# Altium schematic sheet USB.SchDoc
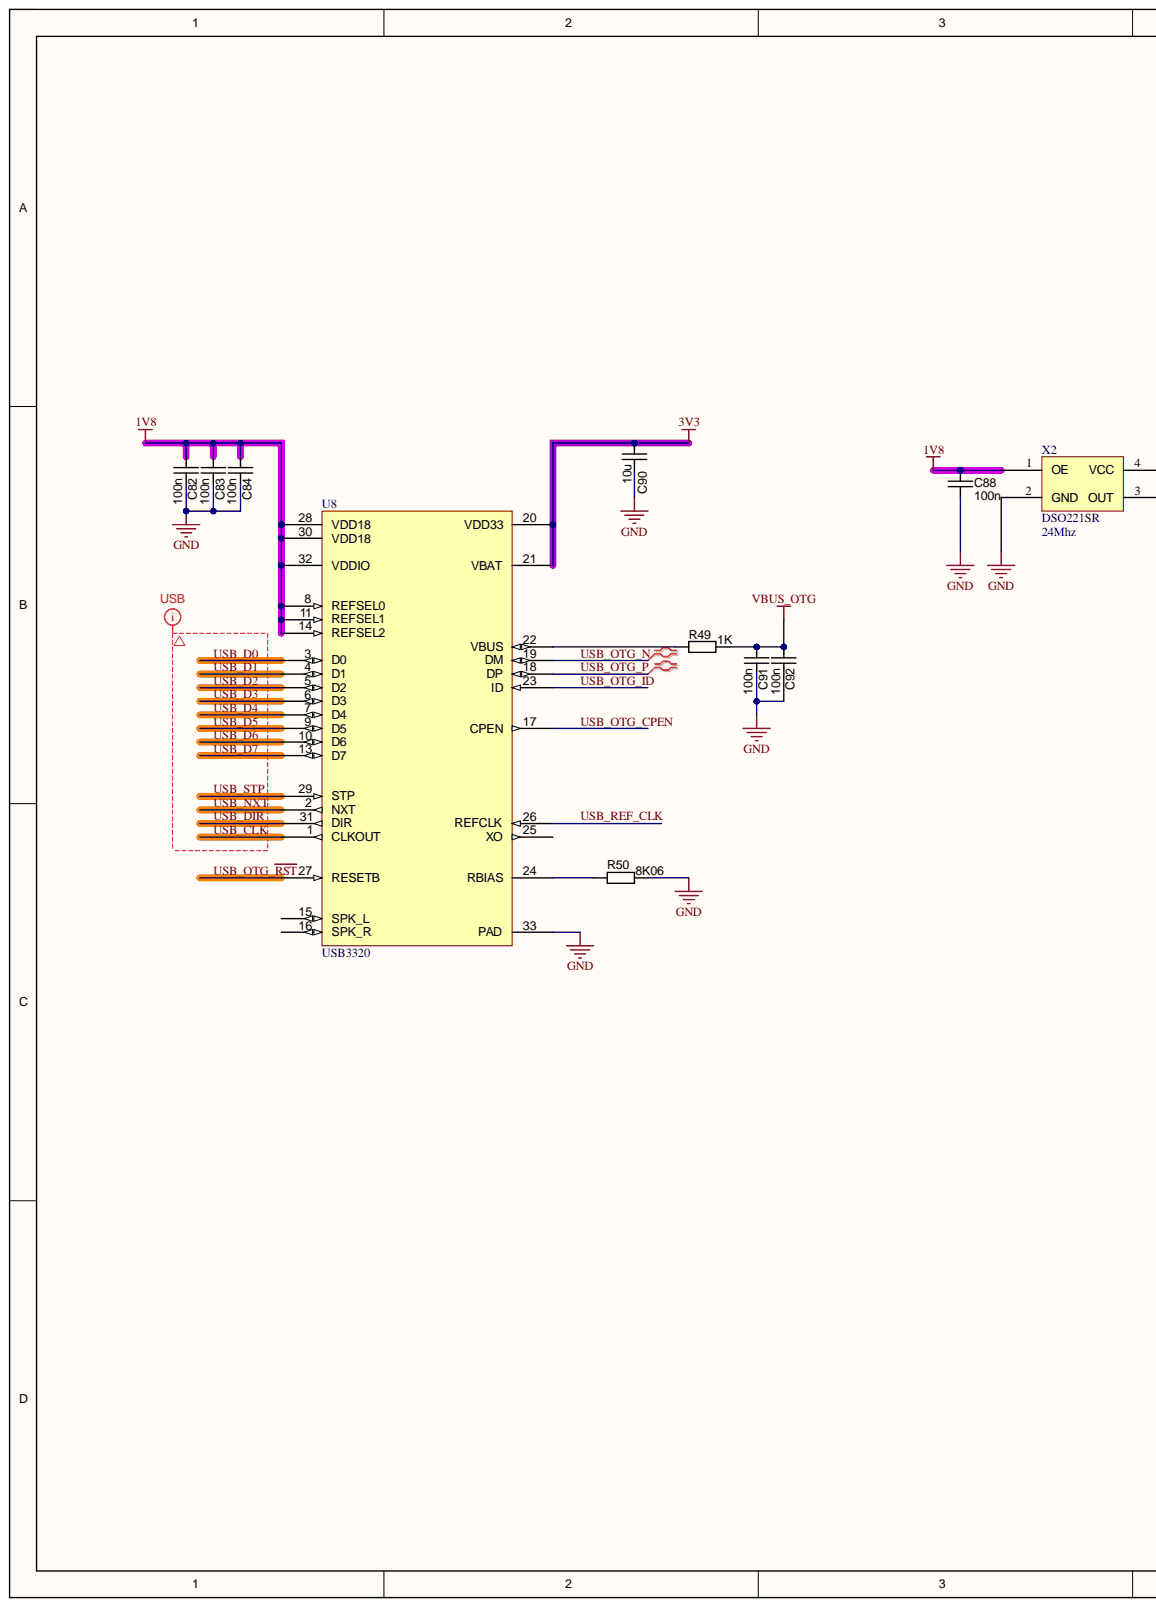
[diagram p0: USB.SchDoc, left]
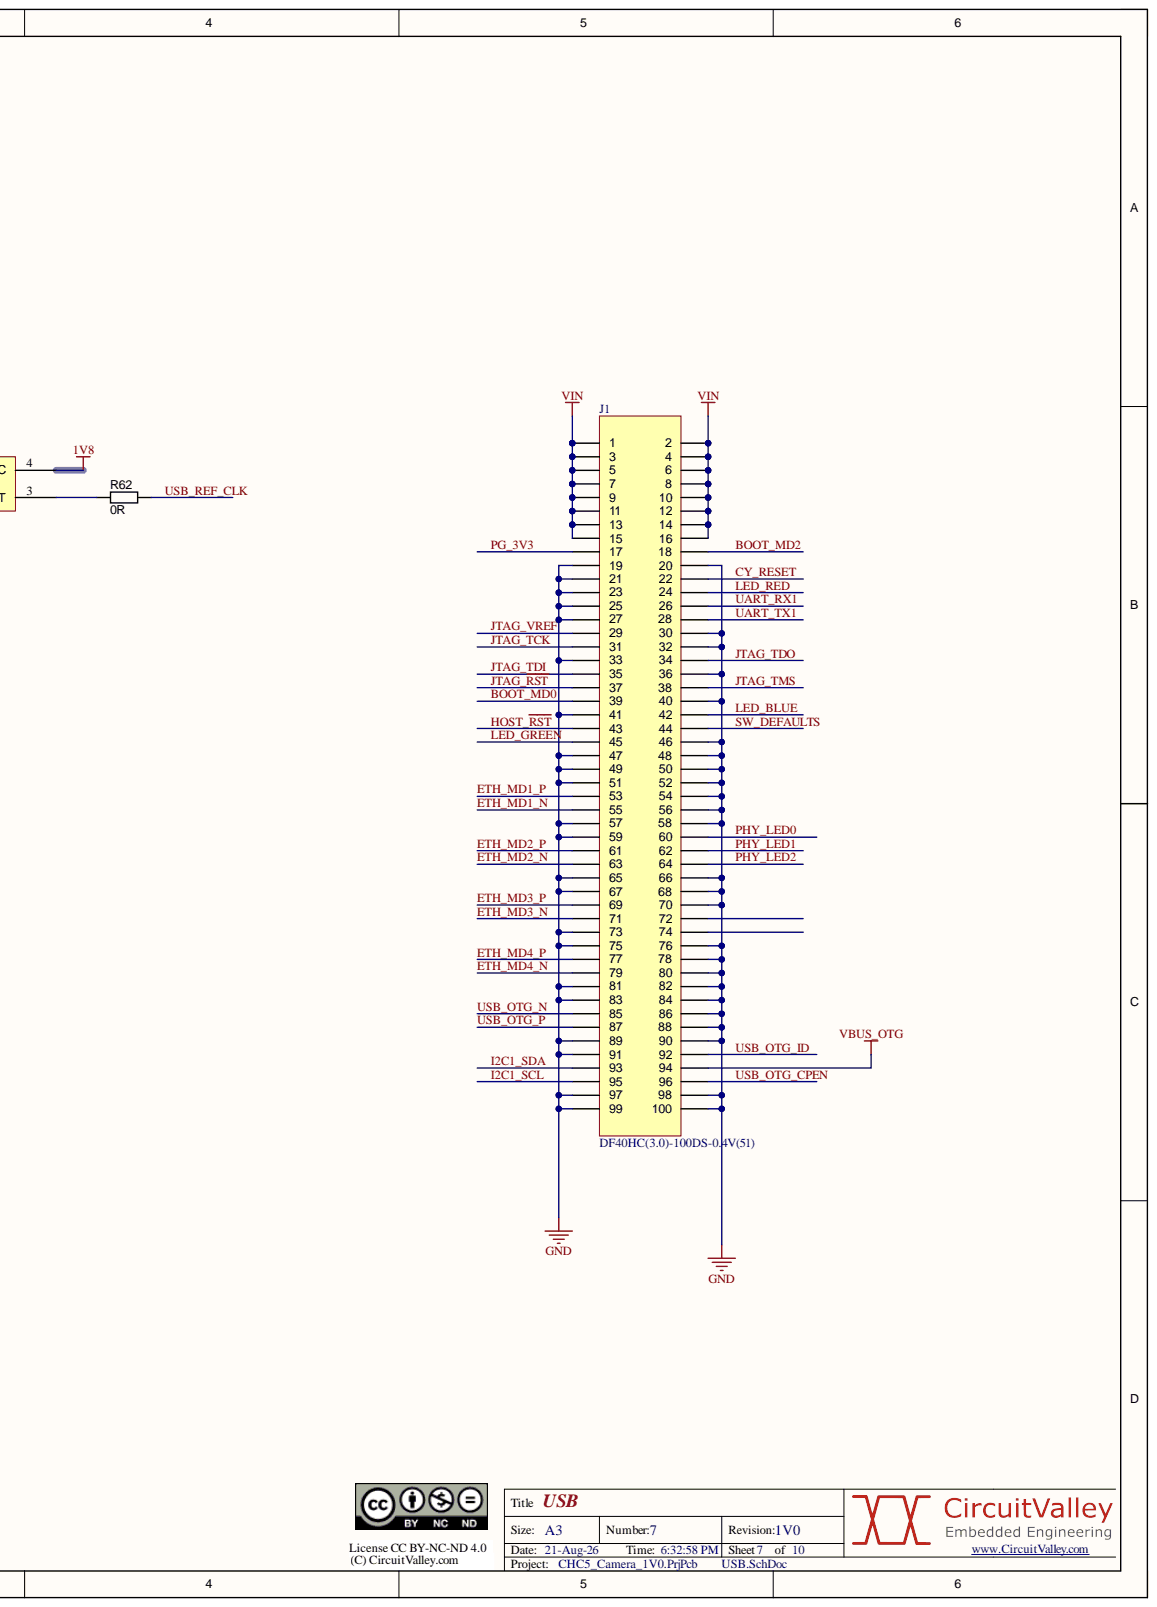
[diagram p1: USB.SchDoc, right]

## Parts
C82  100n  [CAPC1005N]
C83  100n  [CAPC1005N]
C84  100n  [CAPC1005N]
C88  100n  [CAPC1005N]
C90  value 10u  [CAPC1608N]  (hidden comment: approved sub OK)
C91  100n  [CAPC1005N]
C92  100n  [CAPC1005N]
J1  DF40HC(3.0)-100DS-0.4V(51)  [DF40HC(3.0)-100DS-0.4V(51)]
R49  value 1K  [RESC1005N]  (hidden comment: Thick Film)
R50  value 8K06  [RESC1005N]  (hidden comment: Thick Film)
R62  value 0R  [RESC1005N]  (hidden comment: Thick Film)
U8  USB3320  [VQFN32]
X2  DSO221SR  value 24Mhz  [Active Oscillator 2520]

## Nets
1V8: C82.2 C83.2 C84.2 C88.2 U8.8(REFSEL0) U8.11(REFSEL1) U8.14(REFSEL2) U8.28(VDD18) U8.30(VDD18) U8.32(VDDIO) X2.1(OE) X2.4(VCC)
3V3: C90.1 U8.20(VDD33) U8.21(VBAT)
GND: C82.1 C83.1 C84.1 C88.1 C90.2 C91.1 C92.1 J1.19 J1.20 J1.21 J1.23 J1.25 J1.27 J1.30 J1.32 J1.33 J1.36 J1.40 J1.41 J1.46 J1.47 J1.48 J1.49 J1.50 J1.51 J1.52 J1.54 J1.56 J1.57 J1.58 J1.59 J1.65 J1.66 J1.67 J1.68 J1.70 J1.73 J1.75 J1.76 J1.78 J1.80 J1.81 J1.82 J1.83 J1.84 J1.86 J1.88 J1.89 J1.90 J1.91 J1.97 J1.98 J1.99 J1.100 R50.2 U8.33(PAD) X2.2(GND)
VBUS_OTG: C91.2 C92.2 J1.94 R49.2
VIN: J1.1 J1.2 J1.3 J1.4 J1.5 J1.6 J1.7 J1.8 J1.9 J1.10 J1.11 J1.12 J1.13 J1.14 J1.15 J1.16
BOOT_MD0: J1.39
BOOT_MD2: J1.18
CY_RESET: J1.22
ETH_MD1_N: J1.55
ETH_MD1_P: J1.53
ETH_MD2_N: J1.63
ETH_MD2_P: J1.61
ETH_MD3_N: J1.71
ETH_MD3_P: J1.69
ETH_MD4_N: J1.79
ETH_MD4_P: J1.77
HOST_~{RST}: J1.43
I2C1_SCL: J1.95
I2C1_SDA: J1.93
JTAG_~{RST}: J1.37
JTAG_TCK: J1.31
JTAG_TDI: J1.35
JTAG_TDO: J1.34
JTAG_TMS: J1.38
JTAG_VREF: J1.29
LED_BLUE: J1.42
LED_GREEN: J1.45
LED_RED: J1.24
PG_3V3: J1.17
PHY_LED0: J1.60
PHY_LED1: J1.62
PHY_LED2: J1.64
SW_DEFAULTS: J1.44
UART_RX1: J1.26
UART_TX1: J1.28
USB_CLK: U8.1(CLKOUT)
USB_D0: U8.3(D0)
USB_D1: U8.4(D1)
USB_D2: U8.5(D2)
USB_D3: U8.6(D3)
USB_D4: U8.7(D4)
USB_D5: U8.9(D5)
USB_D6: U8.10(D6)
USB_D7: U8.13(D7)
USB_DIR: U8.31(DIR)
USB_NXT: U8.2(NXT)
USB_OTG_CPEN: J1.96 U8.17(CPEN)
USB_OTG_ID: J1.92 U8.23(ID)
USB_OTG_N: J1.85 U8.19(DM)
USB_OTG_P: J1.87 U8.18(DP)
USB_OTG_~{RST}: U8.27(RESETB)
USB_REF_CLK: R62.2 U8.26(REFCLK)
USB_STP: U8.29(STP)
NetR49_1: R49.1 U8.22(VBUS)
NetR50_1: R50.1 U8.24(RBIAS)
NetR62_1: R62.1 X2.3(OUT)

Not connected: J1 72 74; U8 15(SPK_L) 16(SPK_R) 25(XO)

## Library data of the parts
C82-C84 C88 C91 C92 = CAPC_10n_0402_16V_10%: Capacitor SMD Cer 10nF 10% 16V X7R 0402; Manufacturer 1: AVX Corporation; Manufacturer Part Number 1: 0402YC103KAT2A; Ratings: 16V; X7R; Component Kind: Standard; ComponentLink1Description: http://www.avx.com/docs/Catalogs/cx7r.pdf; ComponentLink1URL: http://www.avx.com/docs/Catalogs/cx7r.pdf; ComponentLink2Description: http://www.yageo.com/documents/recent/UPY-GPHC_X7R_6.3V-to-50V_8.pdf; ComponentLink2URL: http://www.yageo.com/documents/recent/UPY-GPHC_X7R_6.3V-to-50V_8.pdf; Library Name: PBL - Capacitors.SCHLIB; Manufacturer 2: Yageo; Manufacturer Part Number 2: CC0402KRX7R7BB103; Operating Temperature: -55°C ~ 125°C; Package / Case: 0402 (1005 Metric); Size / Dimension: 0.039" L x 0.020" W (1.00mm x 0.50mm); Supplier 1: Digi-Key; Supplier 2: Digi-Key; Supplier Part Number 1: 478-1114-1-ND; Supplier Part Number 2: 311-1042-1-ND; Tolerance: ±10%; Value: 100n
C90 = CAPC_560p_0603_5%: Capacitor SMD Cer 560pF 5% 50V NP0 0603; Manufacturer 1: AVX Corporation; Manufacturer Part Number 1: 06035A561JAT2A; Capacitance: 470pF; Material: ceramic; Ratings: 50V; NP0; Applications: General Purpose; Component Kind: Standard; ComponentLink1Description: http://www.avx.com/docs/Catalogs/ccog.pdf; ComponentLink1URL: http://www.avx.com/docs/Catalogs/ccog.pdf; Height - Seated (Max): -; Lead Spacing: -; Lead Style: -; Library Name: PBL - Capacitors.SCHLIB; Mounting Type: Surface Mount, MLCC; Operating Temperature: -55°C ~ 125°C; Package / Case: 0603 (1608 metric); Size / Dimension: 0.063" L x 0.032" W (1.60mm x 0.81mm); Supplier 1: Digi-Key; Supplier Part Number 1: 478-1184-1-ND; Thickness (Max): 0.035" (0.90mm); Voltage - Rated: 50V
J1 = DF40HC(3.0)-100DS-0.4V(51): Hiro 100 pin Connector Receptical; Component Kind: Standard; Library Name: cvlib.SchLib
R49 R50 R62 = RES_3K3_0402_5%: Resistor SMD chip 3.3k Ohm 0.063W 5% 0402; Manufacturer 1: Yageo; Manufacturer Part Number 1: RC0402JR-073K3L; Ratings: 1/16 W; Component Kind: Standard; ComponentLink1Description: http://www.yageo.com/documents/recent/PYu-RC0402_51_RoHS_L_6.pdf; ComponentLink1URL: http://www.yageo.com/documents/recent/PYu-RC0402_51_RoHS_L_6.pdf; Library Name: PBL - Resistors.SCHLIB; Material: Thick Film; Package / Case: 0402 (1005 metric); Supplier 1: Digi-Key; Supplier Part Number 1: 311-3.3KJRCT-ND; Tolerance: ±5%
X2 = Oscillator_2520: Active Oscillator_2520; Component Kind: Standard; Library Name: Crystal_and_oscillator.SchLib
U8 = USB3320: Component Kind: Standard; Library Name: cvlib.SchLib
